# Altium schematic sheet Terminal.SchDoc
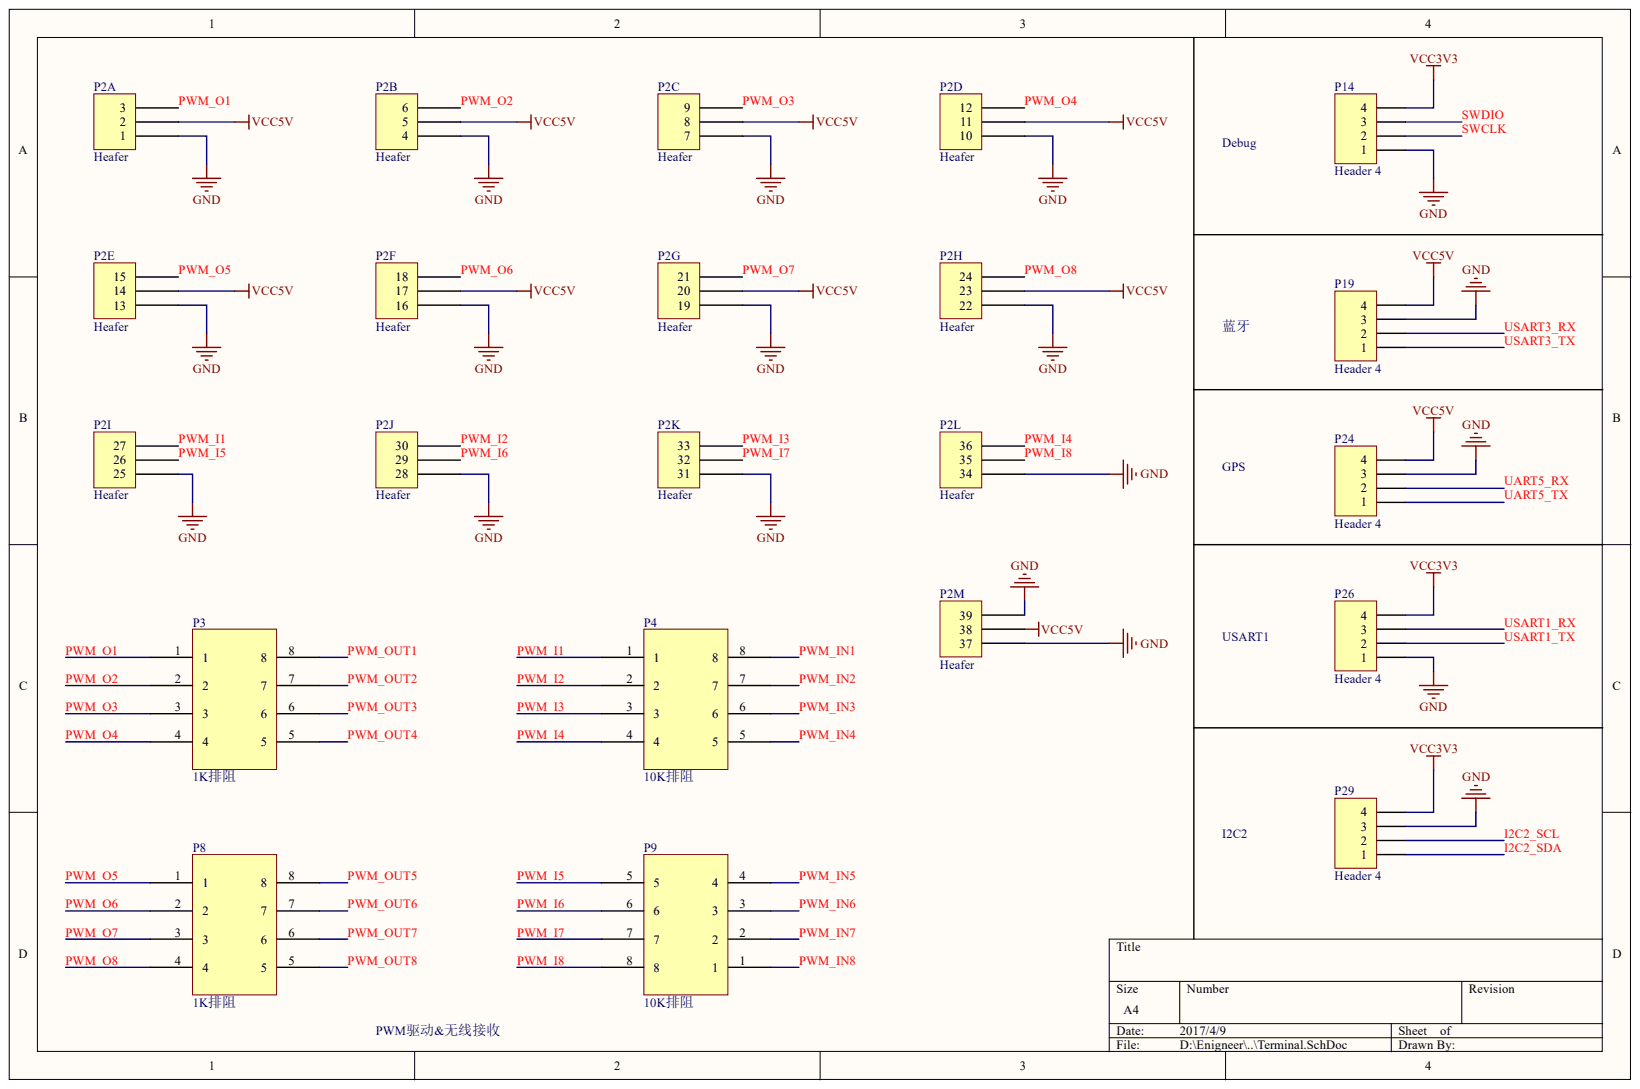
[diagram p0: Terminal.SchDoc]

## Parts
P2  Heafer  [HDR3*13]  (parts A,B,C,D,E,F,G,H,I,J,K,L,M)
P3  1K排阻  [YCI64-0603-8P4R]
P4  10K排阻  [YCI64-0603-8P4R]
P8  1K排阻  [YCI64-0603-8P4R]
P9  10K排阻  [YCI64-0603-8P4R]
P14  Header 4  [HDR1X4]
P19  Header 4  [HDR1X4]
P24  Header 4  [HDR1X4]
P26  Header 4  [HDR1X4]
P29  Header 4  [HDR1X4]

## Nets
GND: P2.1 P2.4 P2.7 P2.10 P2.13 P2.16 P2.19 P2.22 P2.25 P2.28 P2.31 P2.34 P2.37 P2.39 P14.1 P19.3 P24.3 P26.1 P29.3
VCC3V3: P14.4 P26.4 P29.4
VCC5V: P2.2 P2.5 P2.8 P2.11 P2.14 P2.17 P2.20 P2.23 P2.38 P19.4 P24.4
I2C2_SCL: P29.2
I2C2_SDA: P29.1
PWM_I1: P2.27 P4.1
PWM_I2: P2.30 P4.2
PWM_I3: P2.33 P4.3
PWM_I4: P2.36 P4.4
PWM_I5: P2.26 P9.5
PWM_I6: P2.29 P9.6
PWM_I7: P2.32 P9.7
PWM_I8: P2.35 P9.8
PWM_IN1: P4.8
PWM_IN2: P4.7
PWM_IN3: P4.6
PWM_IN4: P4.5
PWM_IN5: P9.4
PWM_IN6: P9.3
PWM_IN7: P9.2
PWM_IN8: P9.1
PWM_O1: P2.3 P3.1
PWM_O2: P2.6 P3.2
PWM_O3: P2.9 P3.3
PWM_O4: P2.12 P3.4
PWM_O5: P2.15 P8.1
PWM_O6: P2.18 P8.2
PWM_O7: P2.21 P8.3
PWM_O8: P2.24 P8.4
PWM_OUT1: P3.8
PWM_OUT2: P3.7
PWM_OUT3: P3.6
PWM_OUT4: P3.5
PWM_OUT5: P8.8
PWM_OUT6: P8.7
PWM_OUT7: P8.6
PWM_OUT8: P8.5
SWCLK: P14.2
SWDIO: P14.3
UART5_RX: P24.2
UART5_TX: P24.1
USART1_RX: P26.3
USART1_TX: P26.2
USART3_RX: P19.2
USART3_TX: P19.1

## Library data of the parts
P2 = Header 3*25: Component Kind: Standard; Library Name: pxLib.SchLib
P14 P19 P24 P26 P29 = Header 4: Header, 4-Pin; Component Kind: Standard; LatestRevisionDate: 17-Jul-2002; LatestRevisionNote: Re-released for DXP Platform.; Library Name: Miscellaneous Connectors.IntLib; Signal Integrity: Connector; Publisher: Altium Limited
P3 P8 = 1K排阻: Component Kind: Standard; Library Name: pxLib.SchLib
P4 P9 = 10K排阻: Component Kind: Standard; Library Name: pxLib.SchLib
